# Altium schematic sheet TTBE - Top Level.SchDoc("TTBE - Top Level")
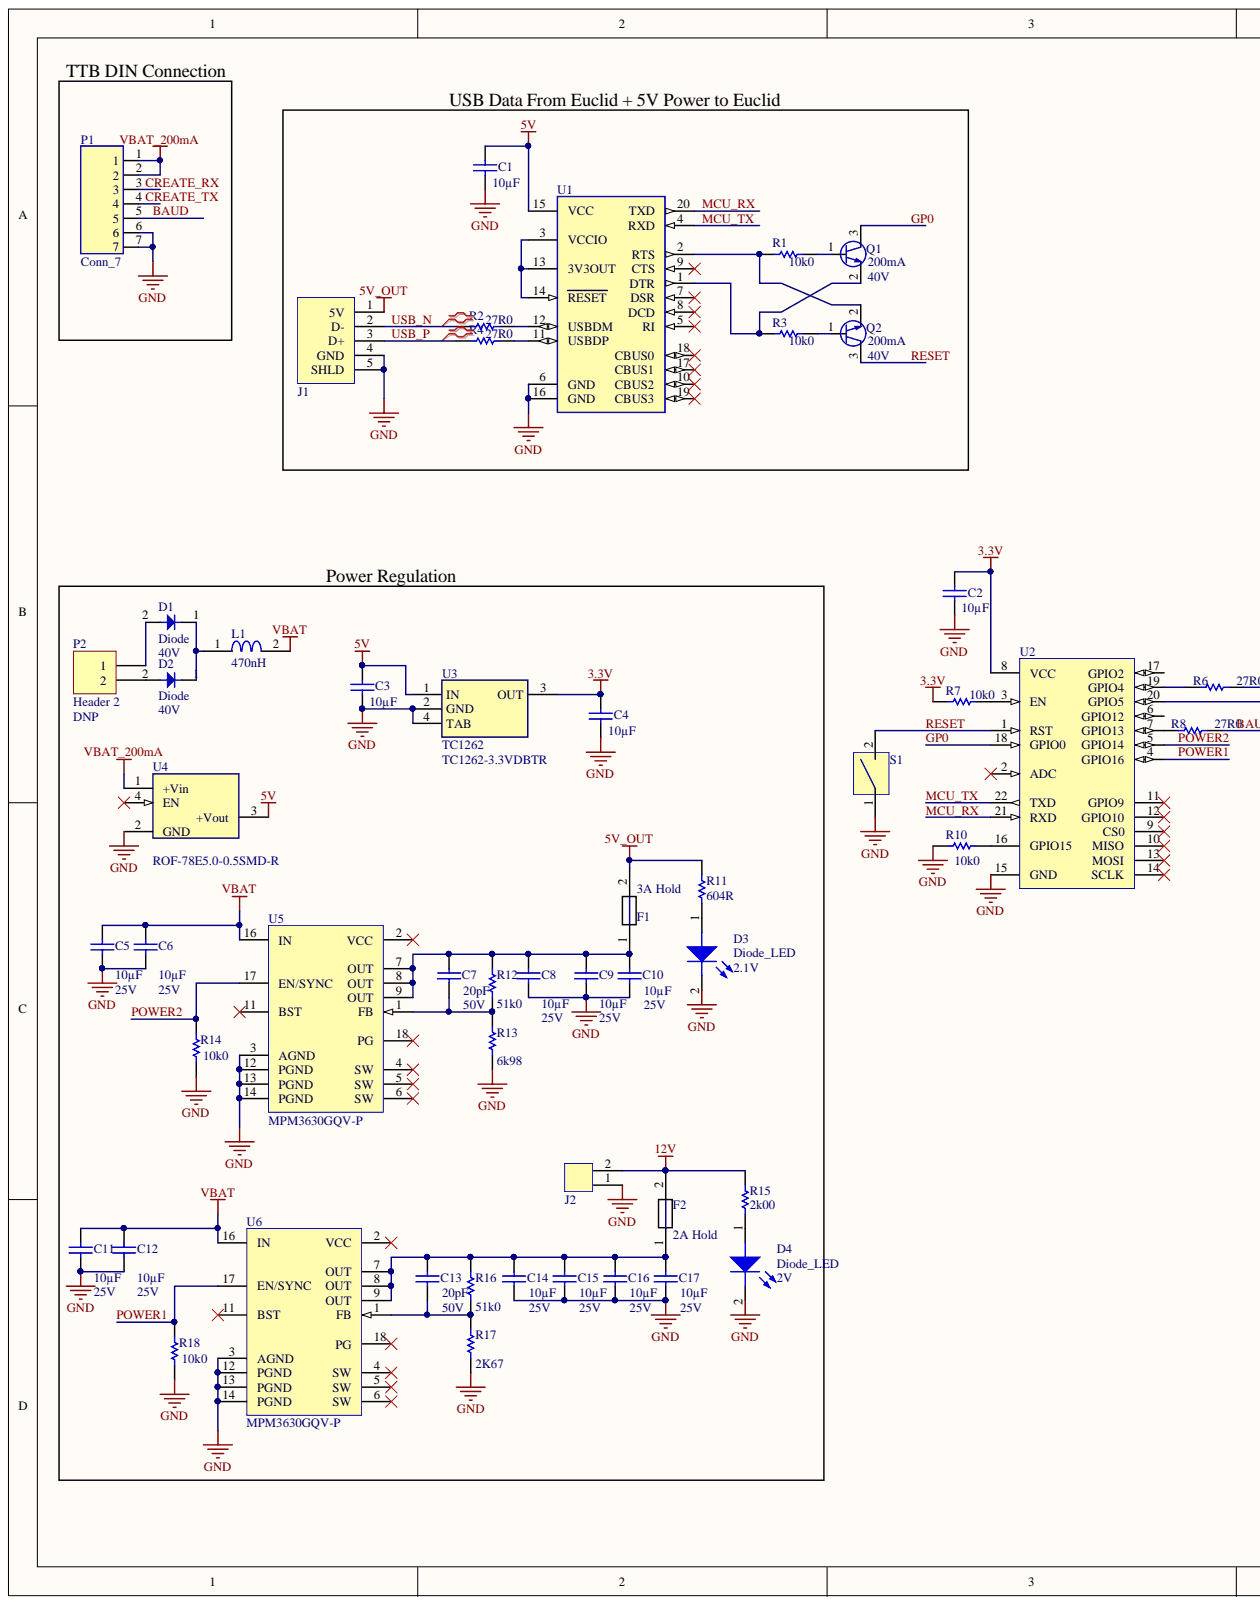
[diagram p0: TTBE - Top Level.SchDoc, left]
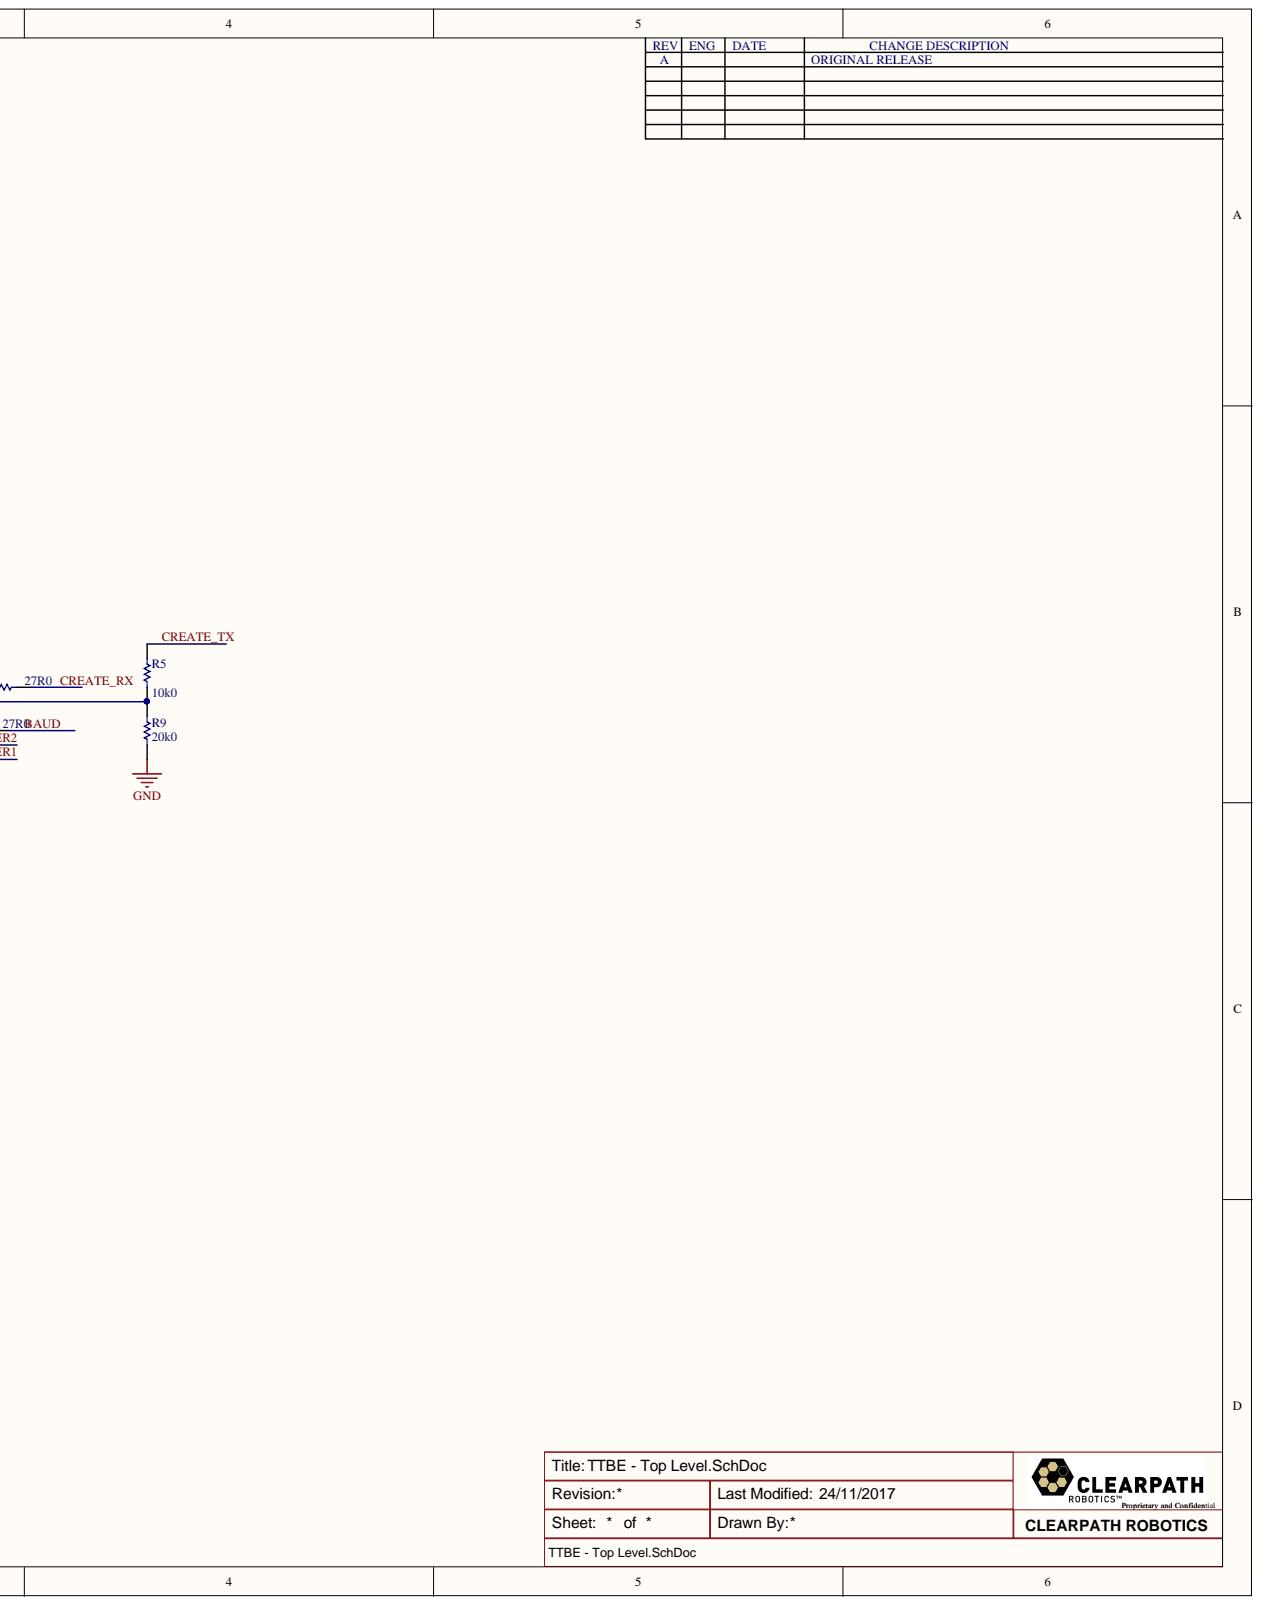
[diagram p1: TTBE - Top Level.SchDoc, right]

## Parts
C1  Capacitance: 10µF  [CAP 0603]  (hidden comment: Cap)
C2  Capacitance: 10µF  [CAP 0603]  (hidden comment: Cap)
C3  Capacitance: 10µF  [CAP 0603]  (hidden comment: Cap)
C4  Capacitance: 10µF  [CAP 0603]  (hidden comment: Cap)
C5  Voltage: 25V  Capacitance: 10µF  [CAP 0603]  (hidden comment: Cap)
C6  Voltage: 25V  Capacitance: 10µF  [CAP 0603]  (hidden comment: Cap)
C7  Voltage: 50V  Capacitance: 20pF  [CAP 0603]  (hidden comment: Cap)
C8  Voltage: 25V  Capacitance: 10µF  [CAP 0603]  (hidden comment: Cap)
C9  Voltage: 25V  Capacitance: 10µF  [CAP 0603]  (hidden comment: Cap)
C10  Voltage: 25V  Capacitance: 10µF  [CAP 0603]  (hidden comment: Cap)
C11  Voltage: 25V  Capacitance: 10µF  [CAP 0603]  (hidden comment: Cap)
C12  Voltage: 25V  Capacitance: 10µF  [CAP 0603]  (hidden comment: Cap)
C13  Voltage: 50V  Capacitance: 20pF  [CAP 0603]  (hidden comment: Cap)
C14  Voltage: 25V  Capacitance: 10µF  [CAP 0603]  (hidden comment: Cap)
C15  Voltage: 25V  Capacitance: 10µF  [CAP 0603]  (hidden comment: Cap)
C16  Voltage: 25V  Capacitance: 10µF  [CAP 0603]  (hidden comment: Cap)
C17  Voltage: 25V  Capacitance: 10µF  [CAP 0603]  (hidden comment: Cap)
D1  Diode  [SMA_DO-214AC]
D2  Diode  Voltage: 40V  [SMA_DO-214AC]
D3  Diode_LED  Voltage: 2.1V  [LED 0805]
D4  Diode_LED  Voltage: 2V  [LED 0805]
F1  Current: 3A Hold  [FUSE 1812]  (hidden comment: Fuse)
F2  Fuse  [FUSE 1812]  (value not drawn)
J1  USB 4 Pin  [734346-1]  (value not drawn)
J2  CONN HEADER 2POS  [0436500212]  (value not drawn)
L1  Inductance: 470nH  [IND 0603]  (hidden comment: Ind)
P1  Conn_7  [MD-70]
P2  Header 2  [HDR1X2]
Q1  Voltage: 40V  Current: 200mA  [SOT-23-3]  (hidden comment: NPN_SOT23)
Q2  Voltage: 40V  Current: 200mA  [SOT-23-3]  (hidden comment: NPN_SOT23)
R1  Resistance: 10k0  [RES 0603]  (hidden comment: Res)
R2  Resistance: 27R0  [RES 0603]  (hidden comment: Res)
R3  Resistance: 10k0  [RES 0603]  (hidden comment: Res)
R4  Resistance: 27R0  [RES 0603]  (hidden comment: Res)
R5  Resistance: 10k0  [RES 0603]  (hidden comment: Res)
R6  Resistance: 27R0  [RES 0603]  (hidden comment: Res)
R7  Resistance: 10k0  [RES 0603]  (hidden comment: Res)
R8  Resistance: 27R0  [RES 0603]  (hidden comment: Res)
R9  Resistance: 20k0  [RES 0603]  (hidden comment: Res)
R10  Resistance: 10k0  [RES 0603]  (hidden comment: Res)
R11  Resistance: 604R  [RES 0603]  (hidden comment: Res)
R12  Resistance: 51k0  [RES 0603]  (hidden comment: Res)
R13  Resistance: 6k98  [RES 0603]  (hidden comment: Res)
R14  Resistance: 10k0  [RES 0603]  (hidden comment: Res)
R15  Resistance: 2k00  [RES 0603]  (hidden comment: Res)
R16  Resistance: 51k0  [RES 0603]  (hidden comment: Res)
R17  Resistance: 2K67  [RES 0603]  (hidden comment: Res)
R18  Resistance: 10k0  [RES 0603]  (hidden comment: Res)
S1  SPST-NO  [FSM2JMTR]  (value not drawn)
U1  FT231XS-R  [SOP64P600X175-20N]  (value not drawn)
U2  ESP-12-F  [ESP-12-F]  (value not drawn)
U3  TC1262  [SOT-223-4]
U4  Output Voltage: 5V  [ROF-78Exx]  (hidden comment: 3 Pin + Enable Regulator)
U5  MPM3630GQV-P  [MPM3630GQV-P]
U6  MPM3630GQV-P  [MPM3630GQV-P]

## Nets
3.3V: C2.2 C4.2 R7.1 U2.8(VCC) U3.3(OUT)
5V: C1.2 C3.2 U1.15(VCC) U3.1(IN) U4.3(+Vout)
5V_OUT: F1.2 J1.1(5V) R11.2
12V: F2.2 J2.2 R15.2
GND: C1.1 C2.1 C3.1 C4.1 C5.1 C6.1 C8.1 C9.1 C10.1 C11.1 C12.1 C14.1 C15.1 C16.1 C17.1 D3.2 D4.2 J1.4(GND) J1.5(SHLD) J2.1 P1.6 P1.7 R9.1 R10.1 R13.1 R14.1 R17.1 R18.1 S1.1 U1.6(GND) U1.16(GND) U2.15(GND) U3.2(GND) U3.4(TAB) U4.2(GND) U5.3(AGND) U5.12(PGND) U5.13(PGND) U5.14(PGND) U6.3(AGND) U6.12(PGND) U6.13(PGND) U6.14(PGND)
VBAT: C5.2 C6.2 C11.2 C12.2 L1.2 U5.16(IN) U6.16(IN)
VBAT_200mA: P1.1 P1.2 U4.1(+Vin)
BAUD: P1.5 R8.2
CREATE_RX: P1.3 R6.2
CREATE_TX: P1.4 R5.2
GP0: Q1.3 U2.18(GPIO0)
MCU_RX: U1.20(TXD) U2.21(RXD)
MCU_TX: U1.4(RXD) U2.22(TXD)
POWER1: R18.2 U2.4(GPIO16) U6.17(EN/SYNC)
POWER2: R14.2 U2.5(GPIO14) U5.17(EN/SYNC)
RESET: Q2.3 S1.2 U2.1(RST)
USB_N: J1.2(D-) R2.1
USB_P: J1.3(D+) R4.1
NetC7_1: C7.1 R12.1 R13.2 U5.1(FB)
NetC7_2: C7.2 C8.2 C9.2 C10.2 F1.1 R12.2 U5.7(OUT) U5.8(OUT) U5.9(OUT)
NetC13_1: C13.1 R16.1 R17.2 U6.1(FB)
NetC13_2: C13.2 C14.2 C15.2 C16.2 C17.2 F2.1 R16.2 U6.7(OUT) U6.8(OUT) U6.9(OUT)
NetD1_1: D1.1 D2.1 L1.1
NetD1_2: D1.2 P2.1
NetD2_2: D2.2 P2.2
NetD3_1: D3.1 R11.1
NetD4_1: D4.1 R15.1
NetQ1_1: Q1.1 R1.2
NetQ1_2: Q1.2 R3.1 U1.1(DTR)
NetQ2_1: Q2.1 R3.2
NetQ2_2: Q2.2 R1.1 U1.2(RTS)
NetR2_2: R2.2 U1.12(USBDM)
NetR4_2: R4.2 U1.11(USBDP)
NetR5_1: R5.1 R9.2 U2.20(GPIO5)
NetR6_1: R6.1 U2.19(GPIO4)
NetR7_2: R7.2 U2.3(EN)
NetR8_1: R8.1 U2.7(GPIO13)
NetR10_2: R10.2 U2.16(GPIO15)
NetU1_3: U1.3(VCCIO) U1.13(3V3OUT) U1.14(RESET)

Not connected: U1 5(RI) 7(DSR) 8(DCD) 9(CTS) 10(CBUS2) 17(CBUS1) 18(CBUS0) 19(CBUS3); U2 2(ADC) 6(GPIO12) 9(CS0) 10(MISO) 11(GPIO9) 12(GPIO10) 13(MOSI) 14(SCLK) 17(GPIO2); U4 4(EN); U5 2(VCC) 4(SW) 5(SW) 6(SW) 11(BST) 18(PG); U6 2(VCC) 4(SW) 5(SW) 6(SW) 11(BST) 18(PG)

## Library data of the parts
C1-C4 = GRM188R61E106MA73D: Capacitor; Manufacturer: Murata Electronics North America; Voltage: 25V; Vendor PN: 490-7202-1-ND; Vendor: Digi-Key; Temperature Coefficient: X5R; ID: 24; Database Table Name: Capacitors; PCB Component: GRM188R61E106MA73D; Library Name: Parts.DbLib; Component Kind: Standard
C5 C6 C8-C12 C14-C17 = GRM188R61E106MA73D: Capacitor; Manufacturer: Murata Electronics North America; Vendor PN: 490-7202-1-ND; Vendor: Digi-Key; Temperature Coefficient: X5R; ID: 24; Database Table Name: Capacitors; PCB Component: GRM188R61E106MA73D; Library Name: Parts.DbLib; Component Kind: Standard
C7 C13 = GRM1885C1H200JA01D: Capacitor; Manufacturer: Murata Electronics North America; Vendor PN: 490-1410-1-ND; Vendor: Digi-Key; Temperature Coefficient: NP0/C0G; ID: 15; Database Table Name: Capacitors; PCB Component: GRM1885C1H200JA01D; Library Name: Parts.DbLib; Component Kind: Standard
D1 D2 = CDBA240LL-HF: 40V, 2A Schottky diode; Manufacturer: Comchip Technology; Vendor PN: 641-1698-1-ND; Vendor: Digi-Key; ID: 17; Database Table Name: Diodes; Type: Schottky; PCB Component: CDBA240LL-HF; Octopart Link: https://octopart.com/cdba240ll-hf-comchip-22883451?r=sp&s=WlHitoGjQImeZn6B8IAMTQ; Library Name: Parts.DbLib; Current: 2A; Component Kind: Standard
  D1: Voltage: 40V
D3 = LTST-C170GKT: Green 0805 surface mount LED; Manufacturer: Lite-On Inc.; Vendor PN: 160-1179-1-ND; Vendor: Digi-Key; Type: Green LED; ID: 11; Database Table Name: Diodes; Current: 10mA; PCB Component: LTST-C170GKT; Octopart Link: https://octopart.com/search?q=LTST-C170GKT; Library Name: Parts.DbLib; Component Kind: Standard
D4 = LTST-C171KRKT: Red 0805 surface mount LED; Manufacturer: Lite-On Inc.; Vendor PN: 160-1427-1-ND; Vendor: Digi-Key; Type: Red LED; ID: 15; Database Table Name: Diodes; Current: 20mA; PCB Component: LTST-C171KRKT; Octopart Link: https://octopart.com/search?q=LTST-C171KRKT; Library Name: Parts.DbLib; Component Kind: Standard
F1 = PTS18126V300: Polymeric PTC Resettable Fuse 6V 3A Ih Surface Mount 1812 (4532 Metric), Concave; Manufacturer: Eaton; Vendor PN: 283-3166-1-ND; Vendor: Digi-Key; Type: Slow Blow Fuse; ID: 37; Database Table Name: Circuit Protection; Voltage: 6V; PCB Component: PTS18126V300; Octopart Link: https://octopart.com/pts18126v300-cooper+bussmann-19675690; Library Name: Parts.DbLib; Component Kind: Standard
F2 = 0ZCG0200AF2B: PTC RESETTBLE 2.0A 16V 1812; Manufacturer: Bel Fuse Inc.; Vendor PN: 507-2074-1-ND; Vendor: Digi-Key; Type: PTC RESETTBLE FUSE; ID: 16; Database Table Name: Circuit Protection; Current: 2A Hold; Voltage: 16V; PCB Component: 0ZCG0200AF2B; Octopart Link: https://octopart.com/0zcg0200af2b-bel+fuse-75578434; Library Name: Parts.DbLib; Component Kind: Standard
J1 = 734346-1: USB - B USB 2.0 Receptacle Connector 4 Position Surface Mount, Right Angle; Manufacturer: TE Connectivity AMP Connectors; Voltage: 30VAC; Vendor PN: A114945-ND; Vendor: Digi-Key; ID: 48; Database Table Name: Connectors; Current: 1A; Type: CONN RCPT USB B BLK 4POS R/A SMD; PCB Component: 734346-1; Octopart Link: https://octopart.com/1734346-1-te+connectivity-40937471; Library Name: Parts.DbLib; Component Kind: Standard
J2 = 0436500212: 2 Positions Header Connector 3.00mm smt; Manufacturer: Molex, LLC; Vendor PN: WM1890CT-ND; Vendor: Digi-Key; ID: 49; Database Table Name: Connectors; Type: CONN HEADER 2POS R/A W/NAIL SMD; PCB Component: 0436500212; Octopart Link: https://octopart.com/0436500212-molex-10467162; Library Name: Parts.DbLib; Component Kind: Standard
L1 = DFE18SANR47ME0L: 470nH Shielded Inductor 2.4A 64 mOhm Max 0603; Manufacturer: Murata Electronics North America; Vendor PN: 490-16580-1-ND; Vendor: Digi-Key; Tolerance: +/- 20%; Resistance: 64 mOhm; ID: 3; Database Table Name: Inductors, Coils; Current - Sat: 3.1A; PCB Component: DFE18SANR47ME0L; Octopart Link: https://octopart.com/dfe18sanr47me0l-murata-85117268?r=sp&s=Gkj9ZwtnRWeGKN8ePFVTBA; Library Name: Parts.DbLib; Component Kind: Standard
P1 = MD-70: 7 Position Circular Connector Plug, Male Pins Solder, Crimp Pin Adapter Available Silver; Manufacturer: CUI Inc; Voltage: 100VAC; Vendor PN: CP-2070-ND; Vendor: Digi-Key; ID: 50; Database Table Name: Connectors; Current: 1A; Type: CONN MINI-DIN 7 PIN MALE; PCB Component: MD-70; Octopart Link: https://octopart.com/md-70-cui-652571; Library Name: Parts.DbLib; Component Kind: Standard
P2 = Header 2: Header, 2-Pin; Signal Integrity: Connector; Library Name: Miscellaneous Connectors.IntLib; LatestRevisionNote: Re-released for DXP Platform.; LatestRevisionDate: 17-Jul-2002; DNP: DNP; Component Kind: Standard; Publisher: Altium Limited
Q1 Q2 = MMBT3904: NPN Transistor; Manufacturer: Fairchild/ON Semiconductor; Vendor PN: MMBT3904FSCT-ND; Vendor: Digi-Key; ID: 5; Database Table Name: Discrete Semiconductors; Type: NPN; PCB Component: MMBT3904; Library Name: Parts.DbLib; Component Kind: Standard
R1 R3 R5 R7 R10 R14 R18 = RC0603FR-0710KL: Resistor; Manufacturer: Yageo; Vendor PN: 311-10.0KHRCT-ND; Vendor: Digi-Key; Tolerance: +/- 1%; Power: 1/10W; ID: 15; Database Table Name: Resistors; PCB Component: RC0603FR-0710KL; Library Name: Parts.DbLib; Component Kind: Standard
R2 R4 R6 R8 = RC0603FR-0727RL: Resistor; Manufacturer: Yageo; Vendor PN: 311-27.0HRCT-ND; Vendor: Digi-Key; Tolerance: +/- 1%; Power: 1/10W; ID: 46; Database Table Name: Resistors; PCB Component: RC0603FR-0727RL; Library Name: Parts.DbLib; Component Kind: Standard
R9 = RC0603FR-0720KL: 20.0 kOhm +/-1% SMD film resistor; Manufacturer: Yageo; Vendor PN: 311-20.0KHRCT-ND; Vendor: Digi-Key; Power: 1/10W; ID: 54; Database Table Name: Resistors; Tolerance: +/- 1%; PCB Component: RC0603FR-0720KL; Octopart Link: https://octopart.com/search?q=RC0603FR-0720KL; Library Name: Parts.DbLib; Component Kind: Standard
R11 = RC0603FR-07604RL: 604 Ohm +/-1% SMD film resistor; Manufacturer: Yageo; Vendor PN: 311-604HRCT-ND; Vendor: Digi-Key; Power: 1/10W; ID: 5; Database Table Name: Resistors; Tolerance: +/- 1%; PCB Component: RC0603FR-07604RL; Octopart Link: https://octopart.com/search?q=RC0603FR-07604RL&start=0; Library Name: Parts.DbLib; Component Kind: Standard
R12 R16 = RC0603FR-0751KL: Resistor; Manufacturer: Yageo; Vendor PN: 311-51.0KHRCT-ND; Vendor: Digi-Key; Tolerance: +/- 1%; Power: 1/10W; ID: 42; Database Table Name: Resistors; PCB Component: RC0603FR-0751KL; Library Name: Parts.DbLib; Component Kind: Standard
R13 = RC0603FR-076K98L: Resistor; Manufacturer: Yageo; Vendor PN: 311-6.98KHRTR-ND; Vendor: Digi-Key; Tolerance: +/- 1%; Power: 1/10W; ID: 43; Database Table Name: Resistors; PCB Component: RC0603FR-076K98L; Library Name: Parts.DbLib; Component Kind: Standard
R15 = RC0603FR-072KL: 2.00 kOhm +/-1% SMD film resistor; Manufacturer: Yageo; Vendor PN: 311-2.00KHRCT-ND; Vendor: Digi-Key; Power: 1/10W; ID: 12; Database Table Name: Resistors; Tolerance: +/- 1%; PCB Component: RC0603FR-072KL; Octopart Link: https://octopart.com/search?q=RC0603FR-072KL&start=0; Library Name: Parts.DbLib; Component Kind: Standard
R17 = RC0603FR-072K67L: Resistor; Manufacturer: Yageo; Vendor PN: 311-2.67KHRCT-ND; Vendor: Digi-Key; Tolerance: +/- 1%; Power: 1/10W; ID: 47; Database Table Name: Resistors; PCB Component: RC0603FR-072K67L; Library Name: Parts.DbLib; Component Kind: Standard
S1 = FSM2JMTR: PushButton; Manufacturer: TE Connectivity; Voltage: 24 VDC; Vendor PN: 450-2146-1-ND; Vendor: Digi-Key; ID: 1; Function: Off-Mom; Database Table Name: Switches; Current: 0.05 A; PCB Component: FSM2JMTR; Octopart Link: https://octopart.com/search?q=FSM2JMTR&start=0; Library Name: Parts.DbLib; Component Kind: Standard; Circuit: SPST-NO
U1 = FT231XS-R: IC USB SERIAL FULL UART 20SSOP; Manufacturer: FTDI; Voltage: 3.3 - 5 V; Vendor PN: 768-1129-1-ND; Vendor: Digi-Key; Protocol: USB <-> Serial; ID: 5; Database Table Name: Interface ICs; Type: USB SERIAL; PCB Component: FT231XS-R; Library Name: Parts.DbLib; Component Kind: Standard
U2 = ESP-12-F: 8bit Microcontroller, with onboard Wifi and EEPROM; Manufacturer: AI-Thinker; Voltage: 3.3-3.6V; Vendor PN: esp-12f-esp8266-wifi-board; Vendor: Electrodragon; ID: 1; Database Table Name: MCU ICs; Type: 8bit MCU Module; PCB Component: ESP-12-F; Octopart Link: http://www.electrodragon.com/product/esp-12f-esp8266-wifi-board/; Library Name: Parts.DbLib; Features: Wifi; Component Kind: Standard
U3 = TC1262-3.3VDBTR: 3.3V Linear LDO regulator; Manufacturer: Microchip Technology; Vendor PN: TC1262-3.3VDBCT-ND; Vendor: Digi-Key; Input Voltage Range: 3.6 - 6V; ID: 11; Database Table Name: Power ICs; Current: 500mA; Type: IC REG LIN 3.3V 500MA SOT223-3; PCB Component: TC1262-3.3VDBTR; Output Voltage: 3.3V; Octopart Link: https://octopart.com/search?q=TC1262-3.3VDBTR&start=0; Library Name: Parts.DbLib; Component Kind: Standard
U4 = ROF-78E5.0-0.5SMD-R: Non-Isolated PoL Module DC DC Converter 1 Output 5V 500mA 9V - 36V Input; Manufacturer: Recom Power; Vendor PN: 945-1690-1-ND; Vendor: Digi-Key; Input Voltage Range: 9V-36V; ID: 13; Database Table Name: Power ICs; Current: DC/DC CONVERTER 5V 500MA OUT SMD; PCB Component: ROF-78E5.0-0.5SMD-R; Octopart Link: https://octopart.com/rof-78e5.0-0.5smd-r-recom+power-24467197; Library Name: Parts.DbLib; Component Kind: Standard
U5 U6 = MPM3630GQV-P: DC DC CONVERTER 0.6V-18V; Manufacturer: Monolithic Power Systems Inc.; Vendor PN: 1589-1253-1-ND; Vendor: Digi-Key; Output Voltage: 0.6 - 18V; Input Voltage Range: 4.5 - 18V; ID: 2; Database Table Name: Power ICs; Current: 3A; Type: Non-Isolated PoL Module; PCB Component: MPM3630GQV-P; Library Name: Parts.DbLib; Component Kind: Standard
